# Altium schematic sheet Schematic Prints("All Documents",Physical)
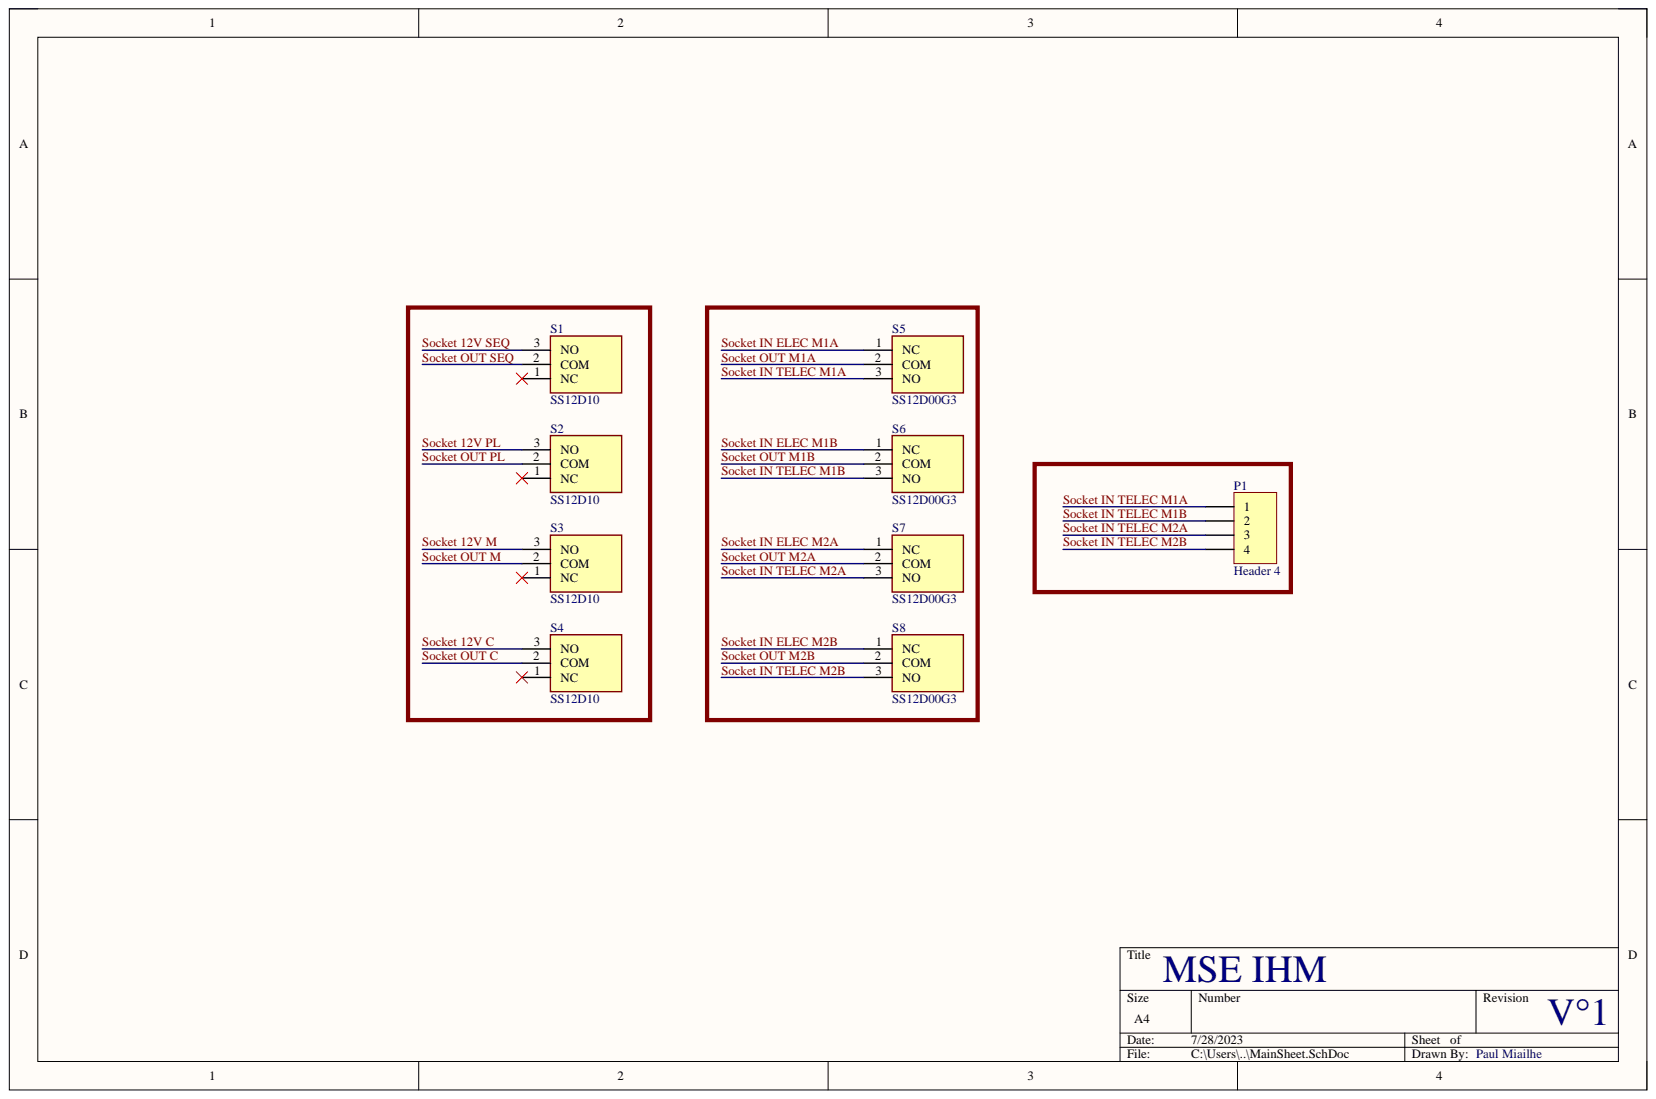
[diagram p0: Schematic Prints("All Documents",Physical)]

## Parts
P1  Header 4  [HDR1X4]
S1  SS12D10  [SS12D10]
S2  SS12D10  [SS12D10]
S3  SS12D10  [SS12D10]
S4  SS12D10  [SS12D10]
S5  SS12D00G3  [SS12D00G3]
S6  SS12D00G3  [SS12D00G3]
S7  SS12D00G3  [SS12D00G3]
S8  SS12D00G3  [SS12D00G3]

## Nets
Socket 12V C: S4.3
Socket 12V M: S3.3
Socket 12V PL: S2.3
Socket 12V SEQ: S1.3
Socket IN ELEC M1A: S5.1
Socket IN ELEC M1B: S6.1
Socket IN ELEC M2A: S7.1
Socket IN ELEC M2B: S8.1
Socket IN TELEC M1A: P1.1 S5.3
Socket IN TELEC M1B: P1.2 S6.3
Socket IN TELEC M2A: P1.3 S7.3
Socket IN TELEC M2B: P1.4 S8.3
Socket OUT C: S4.2
Socket OUT M: S3.2
Socket OUT M1A: S5.2
Socket OUT M1B: S6.2
Socket OUT M2A: S7.2
Socket OUT M2B: S8.2
Socket OUT PL: S2.2
Socket OUT SEQ: S1.2
NetS1_1: S1.1
NetS2_1: S2.1
NetS3_1: S3.1
NetS4_1: S4.1

## Library data of the parts
P1 = Header 4: Header, 4-Pin; Component Kind: Standard; LatestRevisionDate: 17-Jul-2002; LatestRevisionNote: Re-released for DXP Platform.; Library Name: Miscellaneous Connectors.IntLib; Signal Integrity: Connector; Publisher: Altium Limited
S1-S4 = SS12D10: Switch; Manufacturer_Name: DAIER; Component Kind: Standard; Datasheet Link: https://www.chinadaier.com/slide-switch-spdt/; Height: 9.91mm; Manufacturer_Part_Number: SS12D10
S5-S8 = SS12D00G3: Switch; Manufacturer_Name: Vimex; Component Kind: Standard; Datasheet Link: http://vimex.com/switches/techdocs/SS12D00-tech.pdf; Height: 7mm; Manufacturer_Part_Number: SS12D00G3
